# Altium schematic sheet Connectors.SchDoc
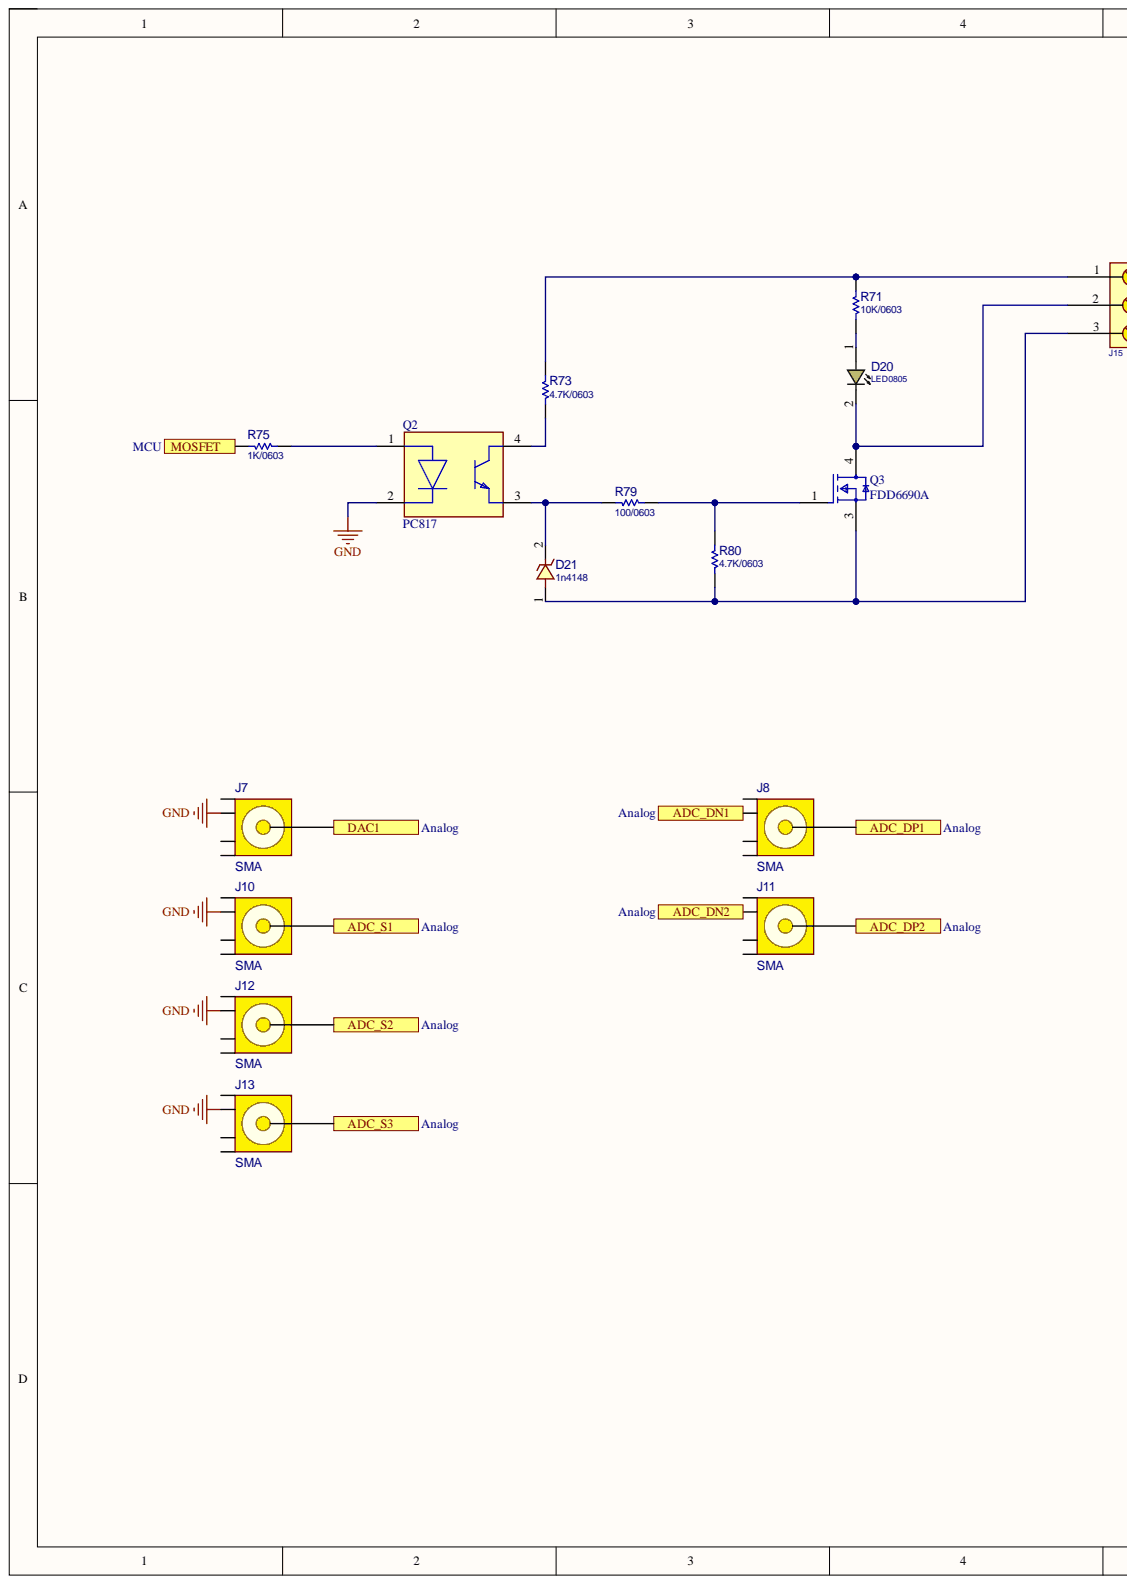
[diagram p0: Connectors.SchDoc, left]
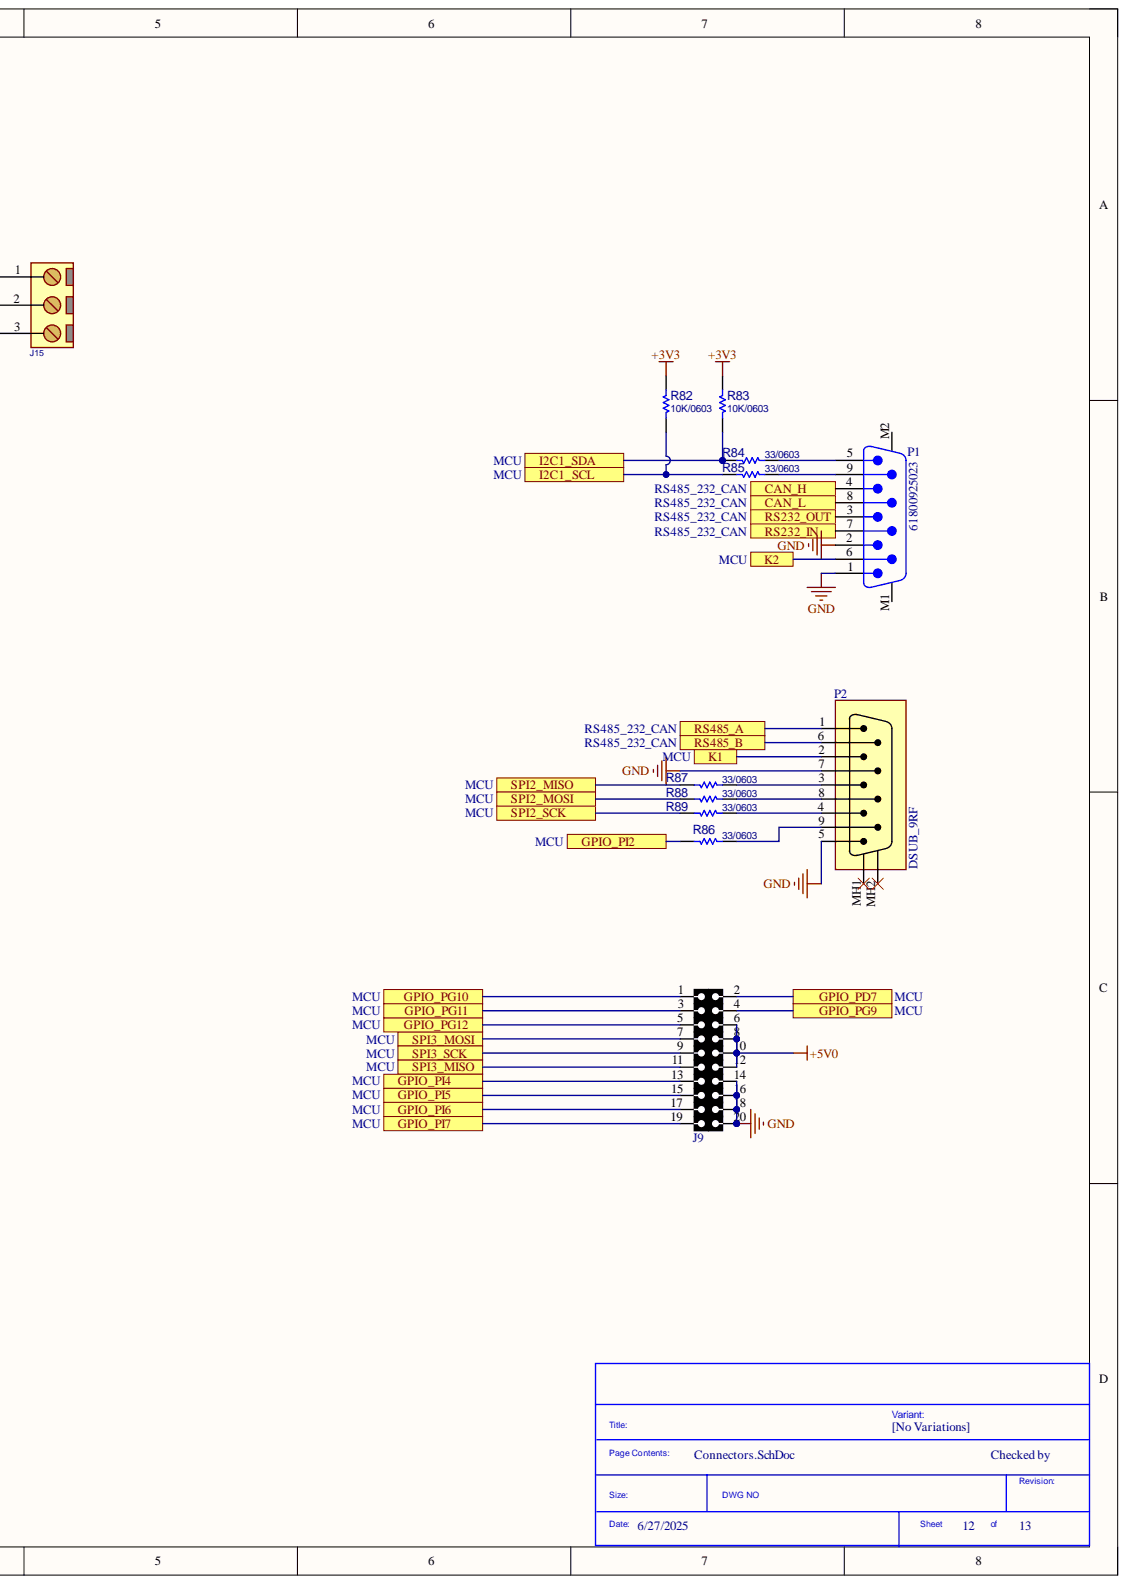
[diagram p1: Connectors.SchDoc, right]

## Parts
D20  LED0805  [LED0805]
D21  1n4148  [D_SOD123W]
J7  SMA  [SMA_RA]
J8  SMA  [SMA_ST]
J9  HDRMINI_2X10  [HDRMINI_F_2X10]  (value not drawn)
J10  SMA  [SMA_RA]
J11  SMA  [SMA_RA]
J12  SMA  [SMA_ST]
J13  SMA  [SMA_ST]
J15  CONN_TB3  [MSTB_3]  (value not drawn)
P1  61800925023  [61800925023]
P2  DSUB_9RF  [DSUB_9RF]
Q2  PC817  [FP-PC817XNNIP0F-MFG]
Q3  FDD6690A  [FP-369AS-MFG]
R71  10K/0603  [R0603]
R73  4.7K/0603  [R0603]
R75  1K/0603  [R0603]
R79  100/0603  [R0603]
R80  4.7K/0603  [R0603]
R82  10K/0603  [R0603]
R83  10K/0603  [R0603]
R84  33/0603  [R0603]
R85  33/0603  [R0603]
R86  33/0603  [R0603]
R87  33/0603  [R0603]
R88  33/0603  [R0603]
R89  33/0603  [R0603]

## Ports
ADC_DN1, ADC_DN2, ADC_DP1, ADC_DP2, ADC_S1, ADC_S2, ADC_S3, CAN_H, CAN_L, DAC1, GPIO_PD7, GPIO_PG9, GPIO_PG10, GPIO_PG11, GPIO_PG12, GPIO_PI2, GPIO_PI4, GPIO_PI5, GPIO_PI6, GPIO_PI7, I2C1_SCL, I2C1_SDA, K1, K2, MOSFET, RS232_IN, RS232_OUT, RS485_A, RS485_B, SPI2_MISO, SPI2_MOSI, SPI2_SCK, SPI3_MISO, SPI3_MOSI, SPI3_SCK

## Nets
+3V3: R82.1 R83.1
+5V0: J9.6 J9.8 J9.10 J9.12
GND: J7.3 J9.14 J9.16 J9.18 J9.20 J10.3 J12.3 J13.3 P1.1 P1.2 P2.5 P2.7 Q2.2
ADC_DN1: J8.3 ; port ADC_DN1
ADC_DN2: J11.3 ; port ADC_DN2
ADC_DP1: J8.1 ; port ADC_DP1
ADC_DP2: J11.1 ; port ADC_DP2
ADC_S1: J10.1 ; port ADC_S1
ADC_S2: J12.1 ; port ADC_S2
ADC_S3: J13.1 ; port ADC_S3
CAN_H: P1.4 ; port CAN_H
CAN_L: P1.8 ; port CAN_L
DAC1: J7.1 ; port DAC1
GPIO_PD7: J9.2 ; port GPIO_PD7
GPIO_PG9: J9.4 ; port GPIO_PG9
GPIO_PG10: J9.1 ; port GPIO_PG10
GPIO_PG11: J9.3 ; port GPIO_PG11
GPIO_PG12: J9.5 ; port GPIO_PG12
GPIO_PI2: R86.1 ; port GPIO_PI2
GPIO_PI4: J9.13 ; port GPIO_PI4
GPIO_PI5: J9.15 ; port GPIO_PI5
GPIO_PI6: J9.17 ; port GPIO_PI6
GPIO_PI7: J9.19 ; port GPIO_PI7
I2C1_SCL: R82.2 R85.1 ; port I2C1_SCL
I2C1_SDA: R83.2 R84.1 ; port I2C1_SDA
K1: P2.2 ; port K1
K2: P1.6 ; port K2
MOSFET: R75.2 ; port MOSFET
RS232_IN: P1.7 ; port RS232_IN
RS232_OUT: P1.3 ; port RS232_OUT
RS485_A: P2.1 ; port RS485_A
RS485_B: P2.6 ; port RS485_B
SPI2_MISO: R87.1 ; port SPI2_MISO
SPI2_MOSI: R88.1 ; port SPI2_MOSI
SPI2_SCK: R89.1 ; port SPI2_SCK
SPI3_MISO: J9.11 ; port SPI3_MISO
SPI3_MOSI: J9.7 ; port SPI3_MOSI
SPI3_SCK: J9.9 ; port SPI3_SCK
NetD20_1: D20.1 R71.2
NetD20_2: D20.2 J15.2 Q3.4
NetD21_1: D21.1 J15.3 Q3.3 R80.2
NetD21_2: D21.2 Q2.3 R79.2
NetJ15_1: J15.1 R71.1 R73.1
NetP1_5: P1.5 R84.2
NetP1_9: P1.9 R85.2
NetP2_3: P2.3 R87.2
NetP2_4: P2.4 R89.2
NetP2_8: P2.8 R88.2
NetP2_9: P2.9 R86.2
NetQ2_1: Q2.1 R75.1
NetQ2_4: Q2.4 R73.2
NetQ3_1: Q3.1 R79.1 R80.1

Not connected: J7 2 4 5; J8 2 4 5; J10 2 4 5; J11 2 4 5; J12 2 4 5; J13 2 4 5; P1 M1 M2; P2 MH1 MH2

## Library data of the parts
D20 = LED_SMD_0805: LED, SMD, 0805; DESC: DESCRIPTION; MANF_P#: MANF_PART#; PARAM2: 2.2V; ASSEMBLY: ASSEMBLY; Component Kind: Standard; Library Name: led(diode).SchLib; MANF: MANF; PRICE: PRICE; VALUE: LED0805
D21 = DIODE_SMD_ZENER_DFLZ5V6: DIODE, ZENER, 1W, 5.1V; DESC: DESCRIPTION; MANF: Diodes; MANF_P#: DFLZ5V6-7; PARAM: 5.6V; PARAM2: 1W, 4Ohm, -55~150oC; ASSEMBLY: ASSEMBLY; Component Kind: Standard; Library Name: diode.SchLib; PRICE: 0.5$; VALUE: 1n4148
J7 J10 J11 = CONN_RF_SMA_RA: CONN, RF, SMA, Right Angle; DESC: DESCRIPTION; DIST#: DIGIKEY; MANF: Samtec; MANF_P#: SMA-J-P-H-RA-TH1; PARAM: 50Ohm, 20GHz; PARAM2: GOLD; ASSEMBLY: ASSEMBLY; Component Kind: Standard; Library Name: conn_rf.SchLib; PRICE: 6.3$; VALUE: SMA
J8 J12 J13 = CONN_RF_SMA_ST: CONN, RF, SMA, STRAIGHT; DESC: DESCRIPTION; DIST#: MOUSER; MANF: Johnson; MANF_P#: 142-0701-201; PARAM: 50Ohm, 18GHz; PARAM2: GOLD; ASSEMBLY: ASSEMBLY; Component Kind: Standard; Library Name: conn_rf.SchLib; PRICE: 2.7$; VALUE: SMA
J9 = HDRMINI_F_2X10: CONN, HEADER, Female, pitch: 1.27mm,; Component Kind: Standard; Library Name: conn_header_1.27mm_st.SchLib; VALUE: HDRMINI_2X10
J15 = CONN_MSTB_3: CONN, PHOENIX MSTB, 5-G, 5.08mm pitch; PARAM: 12A; PARAM2: 320V; Component Kind: Standard; Library Name: conn_terminalB.SchLib; VALUE: CONN_TB3
P1 = CMP-1502-00276-2: NexarPartId: 18818192; Connector Type: D-Sub; Contact Resistance: 30mΩ; Current Rating: 3A; Gender: Male; Insulation Resistance: 5GΩ; Number of Contacts: 9; Operating Supply Voltage: 125V; Pitch: 2.84mm; Component Kind: Standard; Contact Plating: Gold; Depth: 10.9mm; Housing Material: Steel; Insulation Material: PBT; Length: 30.81mm; Library Name: Altium Content Vault; Max Operating Temperature: 85°C; Min Operating Temperature: -40°C; Mount: Through Hole; Number of Pins: 9; Number of Rows: 2; Orientation: Vertical; REACH SVHC: Unknown; RoHS: Compliant; Termination: SMD/SMT; Voltage Rating: 125V; Width: 12.5mm
P2 = CONN_DSUB_9RF: CONN, DSUB, HD, 9PIN, R/A, FEMALE, THD; Component Kind: Standard; Library Name: conn_dsub.SchLib; MANF: -; MANF_P#: -; VALUE: DSUB_9RF
Q2 = CMP-65434-000005-1: OPTOISOLATOR 5KV TRANS 4SMD; Collector Emitter Saturation Voltage: 200mV; Collector Emitter Voltage (VCEO): 80V; Fall Time: 18000ns; Forward Current: 50mA; Forward Voltage: 1.4V; Input Type: DC; Max Power Dissipation: 200mW; Number of Channels: 1; Output Current per Channel: 30mA; Reverse Breakdown Voltage: 6V; Case/Package: SMD/SMT; Collector-emitter Voltage-Max: 200mV; Component Kind: Standard; Contact Plating: Copper; Input Current: 50mA; Isolation Voltage: 5kV; Isolation Voltage-Max: 5kV; Lead Free: Lead Free; Library Name: Altium Content Vault; Max Collector Current: 50mA; Max Input Current: 50mA; Max Operating Temperature: 100°C; Max Output Voltage: 80V; Min Operating Temperature: -30°C; Mount: Surface Mount; Number of Elements: 1; Number of Pins: 4; Output Voltage: 80V; Package Quantity: 2000; Packaging: Tape and Reel; Power Dissipation: 200mW; Radiation Hardening: No; Rise Time: 18000ns; RoHS Compliant: Yes
Q3 = CMP-00278-84325831-1: FDD6690A N-Channel PowerTrench® MOSFET, 30V, 46A, 12mΩ; Manufacturer Lifecycle Status: LAST SHIPMENTS; Element Configuration: Single; Fall Time: 12ns; Gate to Source Voltage (Vgs): 20V; Input Capacitance: 1.23nF; Max Power Dissipation: 1.5W; Nominal Vgs: 1.9V; Number of Elements: 1; Power Dissipation: 3.3W; Rise Time: 7ns; Turn-Off Delay Time: 29ns; Turn-On Delay Time: 10ns; Case/Package: TO-252; Component Kind: Standard; Continuous Drain Current (ID): 46A; Current Rating: 46A; Drain to Source Breakdown Voltage: 30V; Drain to Source Resistance: 12mΩ; Drain to Source Voltage (Vdss): 30V; Dual Supply Voltage: 30V; Height: 2.39mm; Lead Free: Lead Free; Length: 6.73mm; Library Name: Altium Content Vault; Lifecycle Status: EOL; Max Operating Temperature: 175°C; Min Operating Temperature: -55°C; Mount: Surface Mount; NexarPartId: 84325831; Number of Pins: 3; Packaging: Cut Tape; Radiation Hardening: No; Rds On Max: 12mΩ; REACH SVHC: No SVHC; Resistance: 12MΩ; RoHS: Compliant; Threshold Voltage: 1.9V; Voltage Rating (DC): 30V; Weight: 260.37mg; Width: 6.22mm
R71 R73 R75 R79 R80 R82-R89 = RES_SMD_0603: RESISTOR, SMD; DESC: DESCRIPTION; DIST#: MOUSER; PARAM: 1%, 0.1W; ASSEMBLY: ASSEMBLY; Component Kind: Standard; Library Name: passive_smd.SchLib; MANF: -; MANF_P#: -; PARAM2: -; PRICE: -
  R71 R82 R83: VALUE: 10K/0603
  R73 R80: VALUE: 4.7K/0603
  R75: VALUE: 1K/0603
  R79: VALUE: 100/0603
  R84-R89: VALUE: 33/0603
